# Altium schematic sheet Esquematico.SchDoc
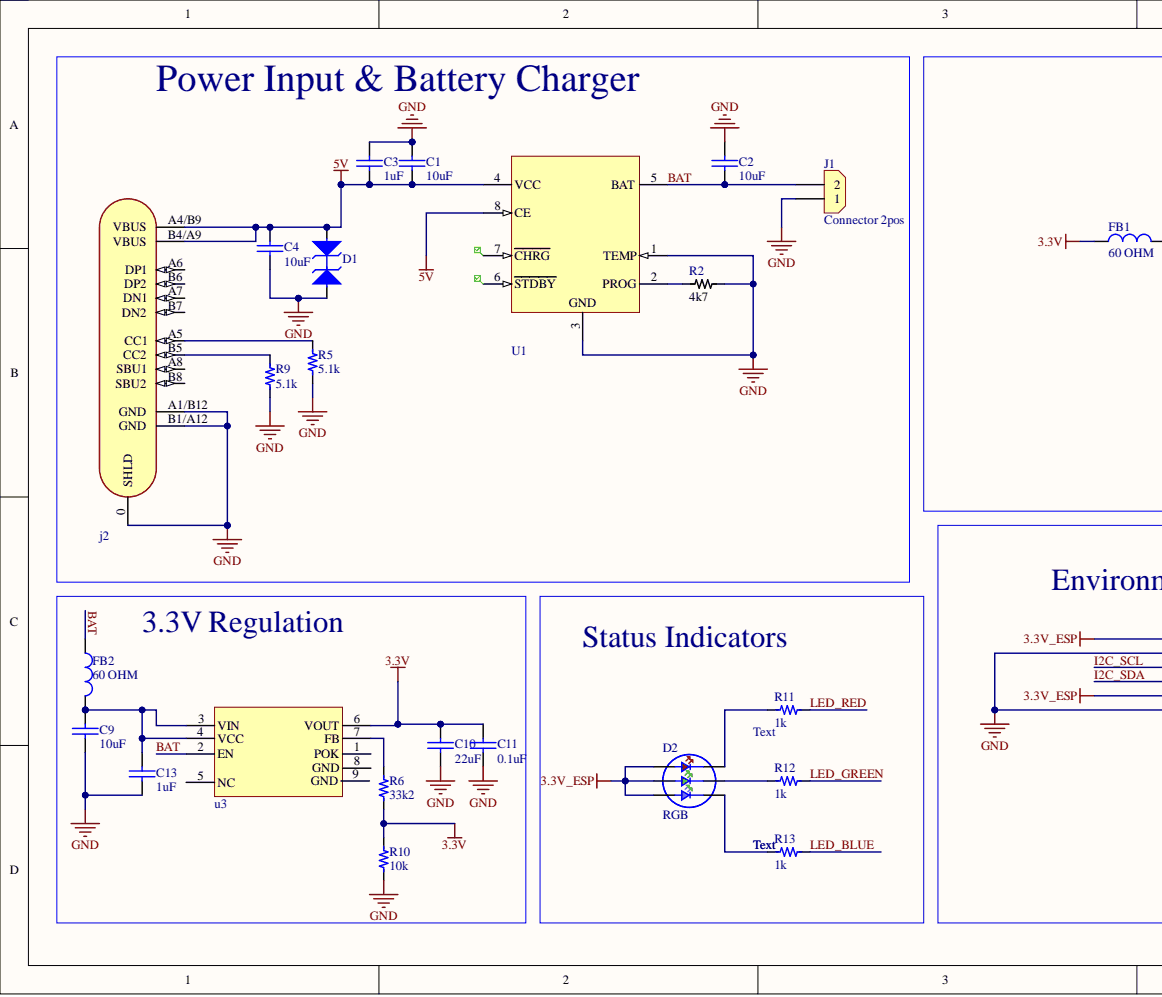
[diagram p0: Esquematico.SchDoc, left]
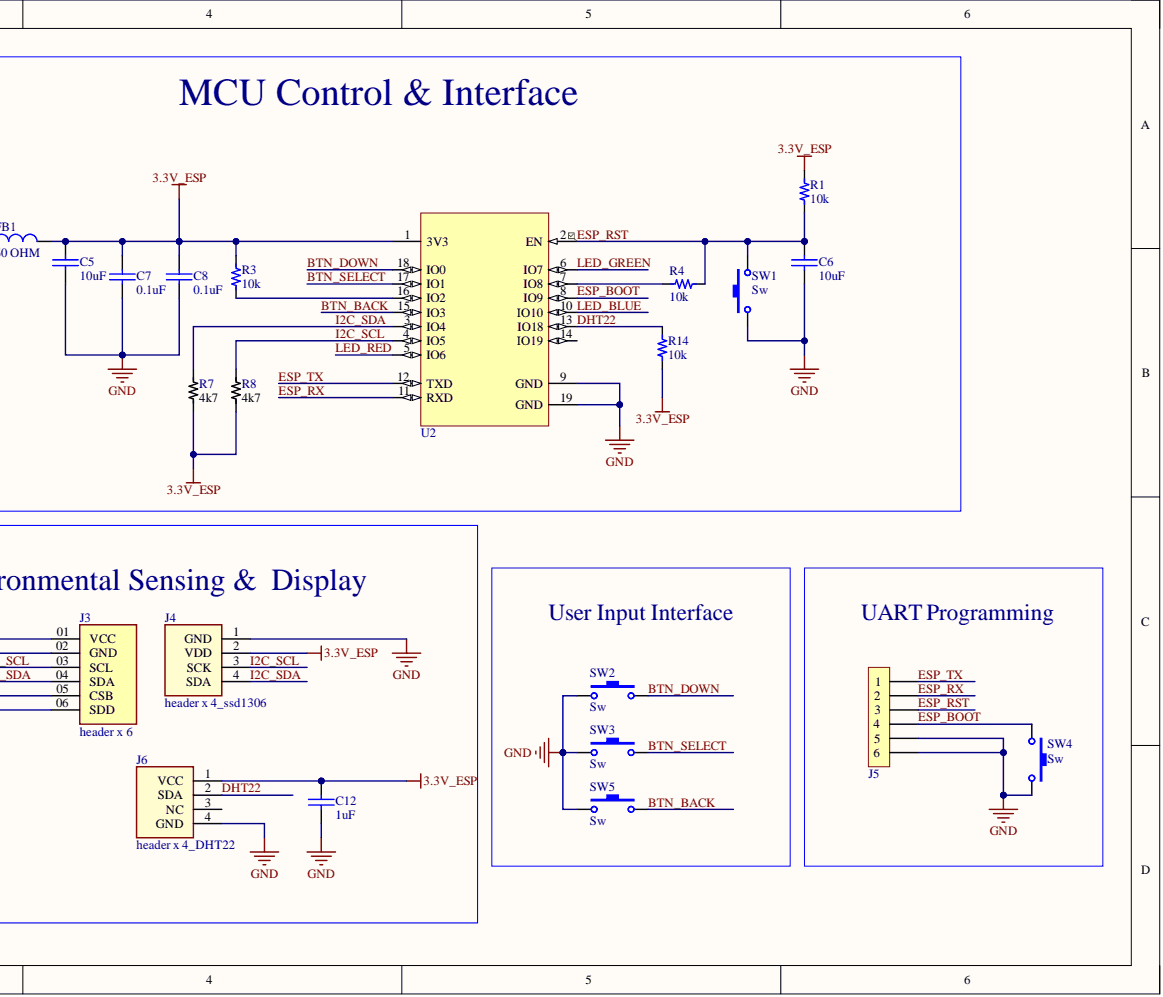
[diagram p1: Esquematico.SchDoc, right]

## Parts
C1  value 10uF  [0603_capacitor]  (hidden comment: 10uF 10V)
C2  value 10uF  [0603_capacitor]  (hidden comment: 10uF 10V)
C3  value 1uF  [0603_cap]  (hidden comment: 1uF 50V)
C4  value 10uF  [0603_capacitor]  (hidden comment: 10uF 10V)
C5  value 10uF  [0603_capacitor]  (hidden comment: 10uF 10V)
C6  value 10uF  [0603_cap]  (hidden comment: 10uF 10V)
C7  value 0.1uF  [0603_cap]  (hidden comment: 0.1uF 50V)
C8  value 0.1uF  [0603_cap]  (hidden comment: 0.1uF 50V)
C9  value 10uF  [0603_capacitor]  (hidden comment: 10uF 10V)
C10  value 22uF  [0805_cap]  (hidden comment: 22uF 6V3)
C11  value 0.1uF  [0603_cap]  (hidden comment: 0.1uF 50V)
C12  value 1uF  [0603_cap]  (hidden comment: 1uF 50V)
C13  value 1uF  [0603_cap]  (hidden comment: 1uF 50V)
D1  TVS 12V  [SOD_123FL]  (value not drawn)
D2  RGB  [RGB_NS_3.5X3.7]
FB1  value 60 OHM  [0603_fb]  (hidden comment: 60OHM 2A)
FB2  value 60 OHM  [0603_fb]  (hidden comment: 60OHM 2A)
J1  Connector 2pos  [CON_2x1_TH_2MM]
j2  USB C  [CON_USB_C_16P_RA]  (value not drawn)
J3  header x 6  [SAMTEC_SSW-106-01-X-S]
J4  header x 4_ssd1306  [SULLINS_PPTC041LFBN-RC_ssd1306]
J5  6x1  [H_6x1_TH_100mil]  (value not drawn)  (no BOM)
J6  header x 4_DHT22  [SULLINS_PPTC041LFBN-RC - DHT22]
R1  value 10k  [0603_res]  (hidden comment: 10k 1/10W)
R2  value 4k7  [0603_resistor]  (hidden comment: 4k7 1/10W)
R3  value 10k  [0603_res]  (hidden comment: 10k 1/10W)
R4  value 10k  [0603_res]  (hidden comment: 10k 1/10W)
R5  value 5.1k  [0603_resistor]  (hidden comment: 5.1kk OHM 0603)
R6  value 33k2  [0603_res]  (hidden comment: 33k2 1/10W)
R7  value 4k7  [0603_resistor]  (hidden comment: 4k7 1/10W)
R8  value 4k7  [0603_resistor]  (hidden comment: 4k7 1/10W)
R9  value 5.1k  [0603_resistor]  (hidden comment: 5.1kk OHM 0603)
R10  value 10k  [0603_res]  (hidden comment: 10k 1/10W)
R11  value 1k  [0603_res]  (hidden comment: 1k 1/10W)
R12  value 1k  [0603_res]  (hidden comment: 1k 1/10W)
R13  value 1k  [0603_res]  (hidden comment: 1k 1/10W)
R14  value 10k  [0603_res]  (hidden comment: 10k 1/10W)
SW1  Sw  [Sw_PUSH_4.5x4.5x5mm]
SW2  Sw  [Sw_PUSH_4.5x4.5x5mm]
SW3  Sw  [Sw_PUSH_4.5x4.5x5mm]
SW4  Sw  [Sw_PUSH_4.5x4.5x5mm]
SW5  Sw  [Sw_PUSH_4.5x4.5x5mm]
U1  4.2V 1A  [SOIC_8_3.9mm]  (value not drawn)
U2  ESP32-C3-WROOM-02-N4  [ESP32-C3-WROOM-02-N4]  (value not drawn)
u3  4.2V 1A  [ESOP]  (value not drawn)

## Nets
3.3V: C10.1 C11.1 FB1.1 R6.1 R10.2 u3.6(VOUT)
3.3V_ESP: C5.1 C7.1 C8.1 C12.1 D2.4 D2.5 D2.6 FB1.2 J3.01(VCC) J3.05(CSB) J4.2(VDD) J6.1(VCC) R1.2 R3.2 R7.1 R8.1 R14.1 U2.1(3V3)
5V: C1.2 C3.2 C4.1 D1.1 j2.A4/B9(VBUS) j2.B4/A9(VBUS) U1.4(VCC) U1.8(CE)
GND: C1.1 C2.2 C3.1 C4.2 C5.2 C6.2 C7.2 C8.2 C9.2 C10.2 C11.2 C12.2 C13.2 D1.2 J1.1 j2.0(SHLD) j2.A1/B12(GND) j2.B1/A12(GND) J3.02(GND) J3.06(SDD) J4.1(GND) J5.5 J5.6 J6.4(GND) R2.2 R5.2 R9.1 R10.1 SW1.1 SW2.1 SW3.1 SW4.2 SW5.1 U1.1(TEMP) U1.3 U2.9(GND) U2.19(GND)
BAT: C2.1 FB2.1 J1.2 U1.5(BAT) u3.2(EN)
BTN_BACK: SW5.2 U2.15(IO3)
BTN_DOWN: SW2.2 U2.18(IO0)
BTN_SELECT: SW3.2 U2.17(IO1)
DHT22: J6.2(SDA) R14.2 U2.13(IO18)
ESP_BOOT: J5.4 SW4.1 U2.8(IO9)
ESP_RST: C6.1 J5.3 R1.1 R4.2 SW1.2 U2.2(EN)
ESP_RX: J5.2 U2.11(RXD)
ESP_TX: J5.1 U2.12(TXD)
I2C_SCL: J3.03(SCL) J4.3(SCK) R8.2 U2.4(IO5)
I2C_SDA: J3.04(SDA) J4.4(SDA) R7.2 U2.3(IO4)
LED_BLUE: R13.2 U2.10(IO10)
LED_GREEN: R12.2 U2.6(IO7)
LED_RED: R11.2 U2.5(IO6)
NetC9_1: C9.1 C13.1 FB2.2 u3.3(VIN) u3.4(VCC)
NetD2_1: D2.1 R13.1
NetD2_2: D2.2 R12.1
NetD2_3: D2.3 R11.1
Netj2_A5: j2.A5(CC1) J6.3(NC) R5.1
Netj2_B5: j2.B5(CC2) R9.2
NetR2_1: R2.1 U1.2(PROG)
NetR3_1: R3.1 U2.16(IO2)
NetR4_1: R4.1 U2.7(IO8)
NetR6_2: R6.2 u3.7(FB)
NetU1_6: U1.6(STDBY) u3.1(POK) u3.5(NC) u3.8(GND) u3.9(GND)

Not connected: j2 A6(DP1) A7(DN1) A8(SBU1) B6(DP2) B7(DN2) B8(SBU2); U1 7(CHRG); U2 14(IO19)

## Library data of the parts
C1 C2 C4-C6 C9 = Cap_10uF_0603_10V: 10V 10uF X5R ±10% 0603 Multilayer Ceramic Capacitors MLCC - SMD/SMT ROHS; Manufacturer: CCTC; Manufacturer Part Number: TCC0603X5R106K100CT; Component Kind: Standard; ComponentLink1Description: LCSC; ComponentLink1URL: https://www.lcsc.com/product-detail/Multilayer-Ceramic-Capacitors-MLCC-SMD-SMT_CCTC-TCC0603X5R106K100CT_C380314.html; Supplier 1: LCSC; Supplier Part Number 1: C380314
  C1 C2 C4 C5 C9: Library Name: libreria_unal.IntLib
  C6: Library Name: shared_lib.IntLib
C3 C12 C13 = Cap_1uF_0603_50V: 50V 1uF X5R ±10% 0603 Multilayer Ceramic Capacitors MLCC - SMD/SMT ROHS; Manufacturer: Samsung Electro-Mechanics; Manufacturer Part Number: CL10A105KB8NNNC; Component Kind: Standard; ComponentLink1Description: LCSC; ComponentLink1URL: https://www.lcsc.com/product-detail/Multilayer-Ceramic-Capacitors-MLCC-SMD-SMT_Samsung-Electro-Mechanics-CL10A105KB8NNNC_C15849.html; Supplier 1: LCSC; Supplier Part Number 1: C15849; Library Name: shared_lib.IntLib
C7 C8 C11 = Cap_0.1uF_0603_50V: 50V 100nF Y5V -20%~+80% 0603 Multilayer Ceramic Capacitors MLCC - SMD/SMT ROHS; Manufacturer: Walsin Tech Corp; Manufacturer Part Number: 0603F104Z500CT; Component Kind: Standard; ComponentLink1Description: LCSC; ComponentLink1URL: https://www.lcsc.com/product-detail/Multilayer-Ceramic-Capacitors-MLCC-SMD-SMT_Walsin-Tech-Corp-0603F104Z500CT_C83060.html; Supplier 1: LCSC; Supplier Part Number 1: C83060; Library Name: shared_lib.IntLib
C10 = Cap_22uF_0805_6V3: 6.3V 22uF X5R ±20% 0805 Multilayer Ceramic Capacitors MLCC - SMD/SMT ROHS; Manufacturer: Samsung Electro-Mechanics; Manufacturer Part Number: CL21A226MQQNNNE; Component Kind: Standard; ComponentLink1Description: LCSC; ComponentLink1URL: https://www.lcsc.com/product-detail/Multilayer-Ceramic-Capacitors-MLCC-SMD-SMT_Samsung-Electro-Mechanics-CL21A226MQQNNNE_C5674.html; Supplier 1: LCSC; Supplier Part Number 1: C5674; Library Name: libreria_unal.IntLib
D1 = TVS_5V_1CH_BID_SOD_123FL: 21.7A 200W@10/1000us 9.2V 7V Bidirectional 5V SOD-123FL ESD and Surge Protection (TVS/ESD) ROHS; Manufacturer: TDSEMIC; Manufacturer Part Number: SMF5.0CA; Component Kind: Standard; ComponentLink1Description: LCSC; ComponentLink1URL: https://lcsc.com/product-detail/ESD-and-Surge-Protection-TVS-ESD_TDSEMIC-SMF5-0CA_C46956338.html; Supplier 1: LCSC; Supplier Part Number 1: C46956338; Library Name: libreria_unal.IntLib
D2 = LED_RGB_3535_SMD: -30℃~+85℃ RGB 110° 3535(mm) Light Emitting Diodes (LED) ROHS; Manufacturer: Foshan NationStar Optoelectronics; Manufacturer Part Number: RS-3535MWAM; Component Kind: Standard; ComponentLink1Description: LCSC; ComponentLink1URL: https://www.lcsc.com/product-detail/Light-Emitting-Diodes-LED_Foshan-NationStar-Optoelectronics-RS-3535MWAM_C842778.html; Supplier 1: LCSC; Supplier Part Number 1: C842778; Library Name: libreria_unal.IntLib
FB1 FB2 = FB_60_0603_2A: 2A 1 100mΩ 60Ω@100MHz ±25% 0603 Ferrite Beads ROHS; Manufacturer: TAI-TECH; Manufacturer Part Number: HCB1608KF-600T20; Component Kind: Standard; ComponentLink1Description: LCSC; ComponentLink1URL: https://www.lcsc.com/product-detail/Ferrite-Beads_TAI-TECH-HCB1608KF-600T20_C369516.html; Supplier 1: LCSC; Supplier Part Number 1: C369516
  FB1: Library Name: libreria_unal.IntLib
  FB2: Library Name: shared_lib.IntLib
J1 = Con_2x1_TH_JST_2MM: 2POS 2MM; Manufacturer: JST; Manufacturer Part Number: S2B-PH-K-S(LF)(SN); Component Kind: Standard; ComponentLink1Description: Digi-Key; ComponentLink1URL: https://lcsc.com/product-detail/Wire-To-Board-Connector_JST-S2B-PH-K-S-LF-SN_C173752.html; Supplier 1: LCSC; Supplier Part Number 1: C173752; Library Name: libreria_unal.IntLib
j2 = CON_USB_TYPEC_16P: 3A USB 3.1 1 Surface Mount 16P Female -40℃~+85℃ Type-C SMD USB Connectors ROHS; Manufacturer: SHOU HAN; Manufacturer Part Number: TYPE-C-3.1-16PIN; Component Kind: Standard; ComponentLink1Description: LCSC; ComponentLink1URL: https://lcsc.com/product-detail/USB-Connectors_SHOU-HAN-TYPE-C-3-1-16PIN_C7507405.html; Supplier 1: LCSC; Supplier Part Number 1: C7507405; Library Name: libreria_unal.IntLib
J3 = header x 6: 6 Position Receptacle Connector 0.100" (2.54mm) Through Hole Gold; Manufacturer: Adam Tech; Manufacturer Part Number: RS1-06-G; Component Kind: Standard; ComponentLink1Description: Digi-key; ComponentLink1URL: https://www.digikey.com/en/products/detail/adam-tech/RS1-06-G/9832050; Supplier 1: Digi-key; Supplier Part Number 1: 2057-RS1-06-G-ND; Library Name: libreria_unal.IntLib
J4 = header x 4_ssd1306: 6 Position Receptacle Connector 0.100" (2.54mm) Through Hole Gold; Manufacturer: Sullins Connector Solutions; Manufacturer Part Number: PPTC041LFBN-RC; Component Kind: Standard; ComponentLink1Description: Digi-key; ComponentLink1URL: https://www.digikey.com/en/products/detail/sullins-connector-solutions/PPTC041LFBN-RC/810144; Supplier 1: Digi-key; Supplier Part Number 1: S7002-ND; Library Name: libreria_unal.IntLib
J5 = H_Male_6x1_TH_100mil: ComponentLink1Description: LCSC; Description: H_Male_6x1_TH_100mil; Library Name: shared_lib.IntLib
J6 = header x 4_DHT22: 6 Position Receptacle Connector 0.100" (2.54mm) Through Hole Gold; Manufacturer: Sullins Connector Solutions; Manufacturer Part Number: PPTC041LFBN-RC; Component Kind: Standard; ComponentLink1Description: Digi-key; ComponentLink1URL: https://www.digikey.com/en/products/detail/sullins-connector-solutions/PPTC041LFBN-RC/810144; Supplier 1: Digi-key; Supplier Part Number 1: S7002-ND; Library Name: libreria_unal.IntLib
R1 R3 R4 R10 R14 = Res_10k_0603_1/10W: RES SMD 10k OHM 5% 1/10W 0603; Manufacturer: FOJAN; Manufacturer Part Number: FRC0603J103TS; Component Kind: Standard; ComponentLink1Description: LCSC; ComponentLink1URL: https://www.lcsc.com/product-detail/Chip-Resistor-Surface-Mount_FOJAN-FRC0603J103TS_C2930027.html; Supplier 1: LCSC; Supplier Part Number 1: C2930027; Library Name: shared_lib.IntLib
R2 R7 R8 = Res_4k7_0603_1/10W: 100mW Thick Film Resistor 75V ±1% 4.7kΩ 0603 Chip Resistor - Surface Mount ROHS; Manufacturer: VO; Manufacturer Part Number: SCR0603F4K7; Component Kind: Standard; ComponentLink1Description: LCSC; ComponentLink1URL: https://lcsc.com/product-detail/Chip-Resistor-Surface-Mount_VO-SCR0603F4K7_C3016318.html; Supplier 1: LCSC; Supplier Part Number 1: C3016318; Library Name: libreria_unal.IntLib
R5 R9 = RES_5.1K_0603_1/10: Manufacturer: Panasonic Industry; Manufacturer PN: P5.1KBDCT-ND; Component Kind: Standard; ComponentLink1Description: Digi-Key; ComponentLink1URL: https://www.digikey.com/en/products/detail/panasonic-industry/ERA-3ARB512V/3073669; Supplier 1: DIgi-Key; Supplier Part Number 1: ERA-3ARB512V; Library Name: libreria_unal.IntLib
R6 = Res_33k2_0603_1/10W: 100mW Thick Film Resistor 75V ±100ppm/℃ ±1% 33.2kΩ 0603 Chip Resistor - Surface Mount ROHS; Manufacturer: YAGEO; Manufacturer Part Number: RC0603FR-0733K2L; Component Kind: Standard; ComponentLink1Description: LCSC; ComponentLink1URL: https://lcsc.com/product-detail/Chip-Resistor-Surface-Mount_YAGEO-RC0603FR-0733K2L_C185338.html; Supplier 1: LCSC; Supplier Part Number 1: C185338; Library Name: shared_lib.IntLib
R11-R13 = Res_1k_0603_1/10W: RES SMD 1k OHM 5% 1/10W 0603; Manufacturer: FOJAN; Manufacturer Part Number: FRC0603J102 TS; Component Kind: Standard; ComponentLink1Description: LCSC; ComponentLink1URL: https://www.lcsc.com/product-detail/Chip-Resistor-Surface-Mount_FOJAN-FRC0603J102-TS_C2907113.html; Supplier 1: LCSC; Supplier Part Number 1: C2907113; Library Name: shared_lib.IntLib
SW1-SW5 = Sw_PUSH_4.5x4.5x5.0xmm: SWITCH TACTILE SPST-NO 0.05A 12V; Manufacturer: BZCN; Manufacturer Part Number: TSC015A05026A; Component Kind: Standard; ComponentLink1Description: LCSC; ComponentLink1URL: https://www.lcsc.com/product-detail/Tactile-Switches_BZCN-TSC015A05026A_C2888509.html; Last modified date: 20190222; Supplier 1: LCSC; Supplier Part Number 1: C2888509; Last modified by: N-A.Potosi; Library Name: libreria_unal.IntLib
U1 = IC_BAT_CHG_4.2V_1A: -300mV~8V Lithium-ion/Polymer 1 1A ESOP-8 Battery Management ROHS; Manufacturer: YONGYUTAI; Manufacturer Part Number: TP4056; Component Kind: Standard; ComponentLink1Description: LCSC; ComponentLink1URL: https://lcsc.com/product-detail/Battery-Management_YONGYUTAI-TP4056_C20199357.html; Supplier 1: LCSC; Supplier Part Number 1: C20199357; Library Name: libreria_unal.IntLib
U2 = MCU_ESP32-C3-WROOM-02-N4: RF TXRX MOD BT WIFI PCB TH SMD; Manufacturer: Espressif Systems; Component Kind: Standard; ComponentLink1Description: LCSC; ComponentLink1URL: https://lcsc.com/product-detail/WiFi-Modules_Espressif-Systems-ESP32-C3-WROOM-02-N4_C2934560.html; Manufacturer Part Number: ESP32-C3-WROOM-02-N4; Supplier 1: LCSC; Supplier Part Number 1: C2934560; Library Name: libreria_unal.IntLib
u3 = DSTECH DS8570F8: Manufacturer: DSTECH; Component Kind: Standard; ComponentLink1Description: LCSC; ComponentLink1URL: https://www.lcsc.com/product-detail/voltage-regulators-linear-low-drop-out-ldo-regulators_dstech-ds8570f8_C5884245.html; Manufacturer Part Number: DS8570F8; Supplier 1: LCSC; Supplier Part Number 1: C20199357; Library Name: libreria_unal.IntLib
